# Altium schematic sheet Pulser.SchDoc
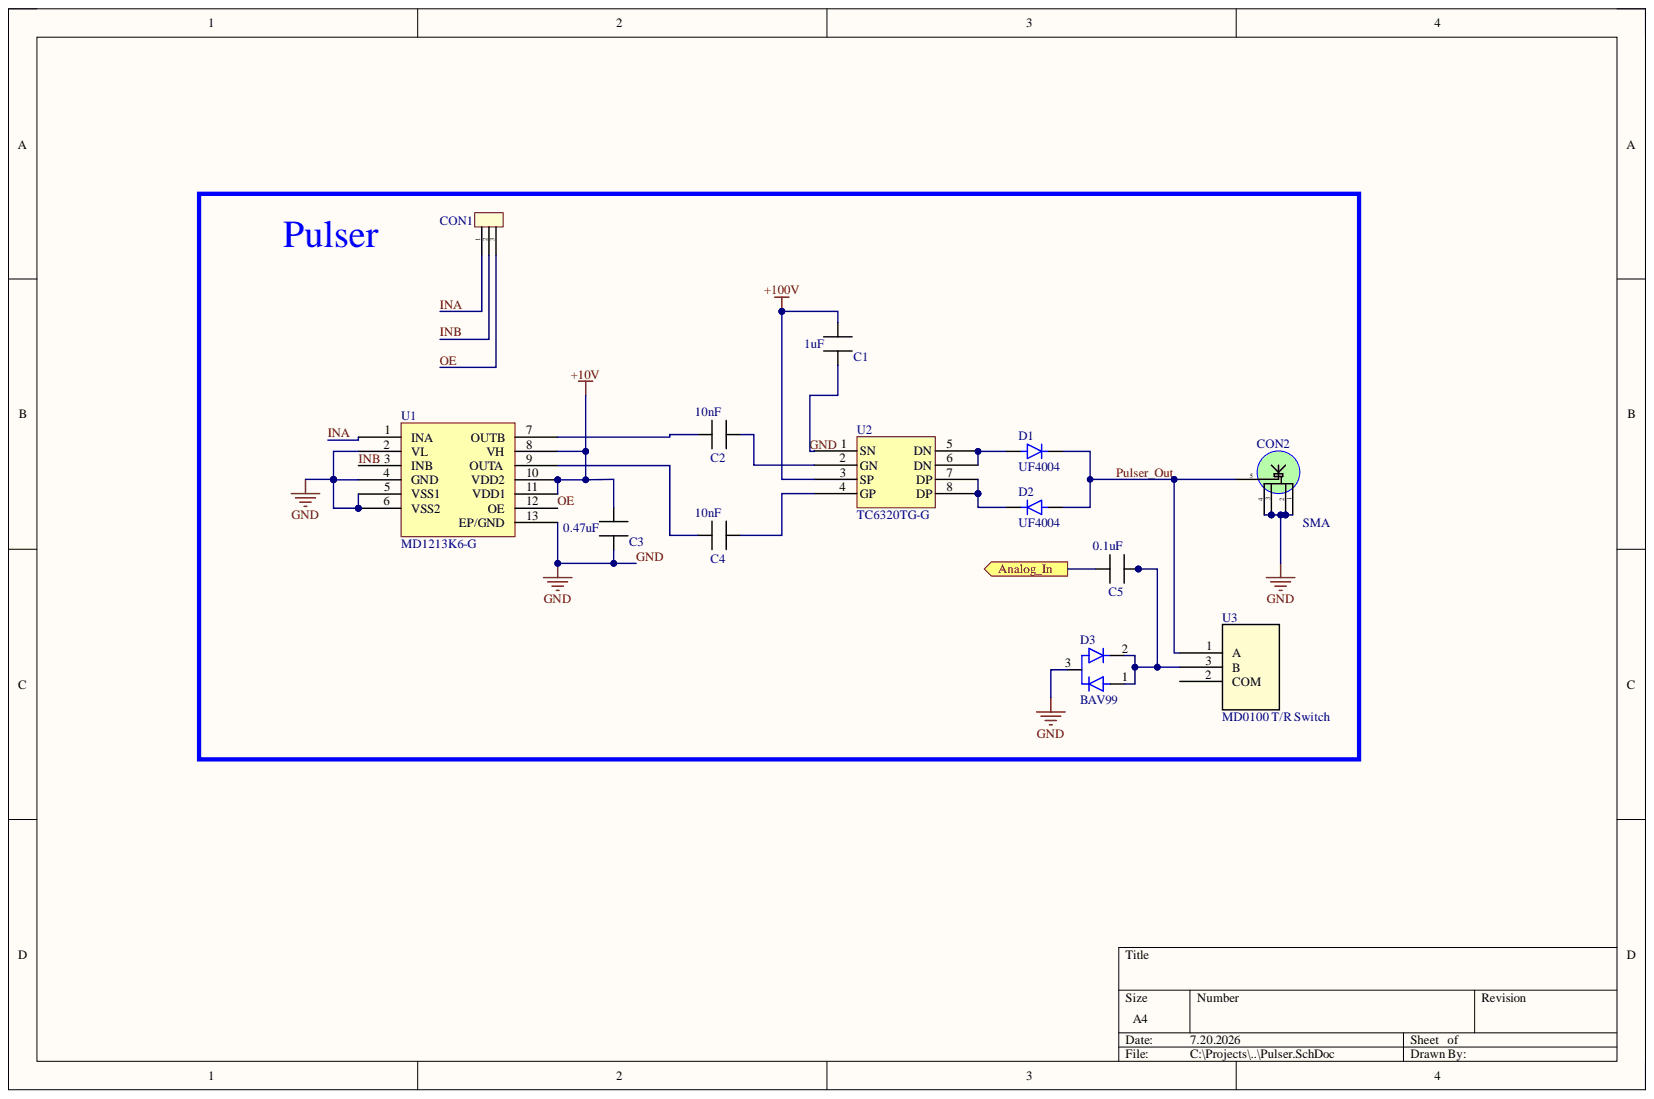
[diagram p0: Pulser.SchDoc]

## Parts
C1  1uF  [CAP_1206]
C2  10nF  [CAP_0805]
C3  0.47uF  [CAP_0805]
C4  10nF  [CAP_0805]
C5  0.1uF  [CAP_0805]
CON1  1x3 2.54mm THT  [CON_1x3_2.54MM_THT]  (value not drawn)
CON2  SMA  [A-SMA-KWE-15.1A]
D1  UF4004  [DIOAD1055W87L533D272]
D2  UF4004  [DIOAD1055W87L533D272]
D3  BAV99  [DIO_BAV99]
U1  MD1213K6-G  [QFN80P400X400X90-13N]
U2  TC6320TG-G  [SOIC127P600X175-8N]
U3  MD0100 T/R Switch  [TO-243AA_MCH]

## Ports
Analog_In

## Nets
+10V: C3.1 U1.8(VH) U1.10(VDD2) U1.11(VDD1)
+100V: C1.2 U2.3(SP)
Analog_In: C5.1 ; port Analog_In
GND: C1.1 C3.2 CON2.1 CON2.2 CON2.3 CON2.4 D3.3 U1.2(VL) U1.4(GND) U1.5(VSS1) U1.6(VSS2) U1.13(EP/GND) U2.1(SN)
INA: CON1.1 U1.1(INA)
INB: CON1.2 U1.3(INB)
OE: CON1.3 U1.12(OE)
Pulser_Out: CON2.5 D1.C D2.A U3.1
NetC2_1: C2.1 U1.7(OUTB)
NetC2_2: C2.2 U2.2(GN)
NetC4_1: C4.1 U1.9(OUTA)
NetC4_2: C4.2 U2.4(GP)
NetC5_2: C5.2 D3.1 D3.2 U3.3
NetD1_A: D1.A U2.5(DN) U2.6(DN)
NetD2_C: D2.C U2.7(DP) U2.8(DP)

Not connected: U3 2

## Library data of the parts
C1 = CAP_1206: note: any manufacturer; Component Kind: Standard; Description: CAP_1206; Library Name: Passives.SchLib; val: 1uF
C2-C5 = CAP_0805: note: any manufacturer; Component Kind: Standard; Description: CAP_0805; Library Name: Passives.SchLib
  C2 C4: val: 10nF
  C3: val: 0.47uF
  C5: val: 0.1uF
CON1 = CON_1x3_2.54mm_THT: note: any manufacturer; Component Kind: Standard; Description: CON_1x3_2.54mm_THT; Library Name: Connectors.SchLib; val: 1x3 2.54mm THT
CON2 = A-SMA-KWE-15.1A: SMA Connector - 1 Inner Bore SMA Board Side Elbow 6GHz 50Ω 5.35mm Through Hole Coaxial Connector (RF) Adapters RoHS; val: MyAntenna A-SMA-KWE-15.1A; Component Kind: Standard; Library Name: Connectors.SchLib; sample link: https://www.lcsc.com/product-detail/C49253491.html?s_z=n_SMA
D1 D2 = UF4004: Diode Standard 400V 1A Through Hole DO-204AL (DO-41); MF: ON Semiconductor; Check_prices: https://www.snapeda.com/parts/UF4004/Onsemi/view-part/?ref=eda; Component Kind: Standard; Library Name: Passives.SchLib; MP: UF4004; Package: DO-41-2 ON Semiconductor; SnapEDA_Link: https://www.snapeda.com/parts/UF4004/Onsemi/view-part/?ref=snap
D3 = BAV99: Diode Array 1 Pair Series Connection Standard 100V 215mA (DC) Surface Mount TO-236-3, SC-59, SOT-23-3; MF: ON Semiconductor; Availability: In Stock; Check_prices: https://www.snapeda.com/parts/BAV99/Onsemi/view-part/?ref=eda; Component Kind: Standard; Library Name: Passives.SchLib; MP: BAV99; Package: SOT-23-3 Motorola; Price: None; Purchase-URL: https://pricing.snapeda.com/search/part/BAV99/?ref=eda; SnapEDA_Link: https://www.snapeda.com/parts/BAV99/Onsemi/view-part/?ref=snap
U3 = MD0100N8-G: Description; Manufacturer_Name: Microchip; Component Kind: Standard; Library Name: IC_Digital.SchLib; Manufacturer_Part_Number: MD0100N8-G; RefDes: RefDes; Type: Type; Copyright: Copyright (C) 2025 Ultra Librarian. All rights reserved.
U1 = MD1213K6-G: Component Kind: Standard; Library Name: IC_Digital.SchLib
U2 = TC6320TG-G: Component Kind: Standard; Library Name: IC_Digital.SchLib
